# Altium schematic sheet stm32wl_Power.SchDoc(stm32wl_Power)
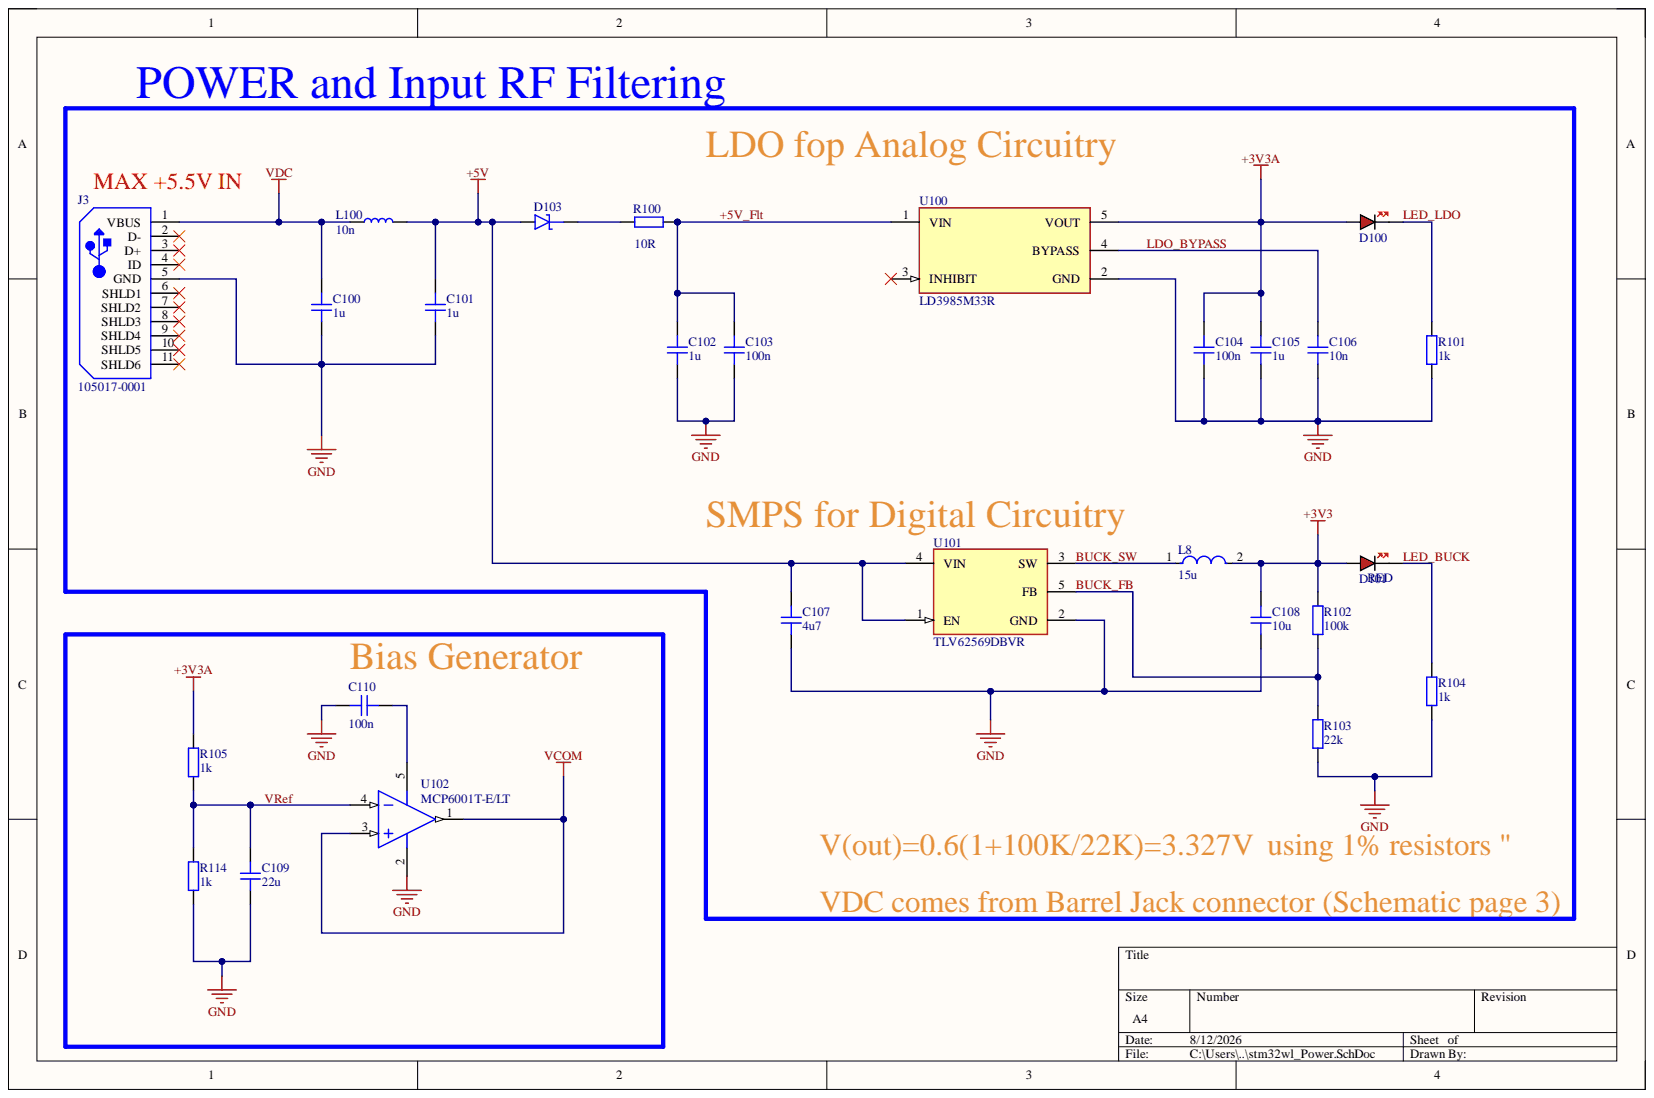
[diagram p0: stm32wl_Power.SchDoc]

## Parts
C100  1u  [CAP_0603]
C101  1u  [CAP_0603]
C102  1u  [CAP_0603]
C103  100n  [CAP_0603]
C104  100n  [CAP_0603]
C105  1u  [CAP_0603]
C106  10n  [CAP_0603]
C107  4u7  [CAP_0603]
C108  10u  [CAP_0603]
C109  22u  [CAP_0603]
C110  100n  [CAP_0402]
D100  RED  [LED_0402_RED]  (value not drawn)
D101  RED  [LED_0402_RED]
D103  D_SCHOTTKY_SOD_323  [SOD-323]  (value not drawn)
J3  105017-0001  [USB-MICRO-B_V]
L8  15u  [FP-IHLP-2020CZ-FP_1_905x2-MFG]
L100  10n  [IND_0603]
R100  10R  [RES_0603]
R101  1k  [RES_0402]
R102  100k  [RES_0402]
R103  22k  [RES_0402]
R104  1k  [RES_0402]
R105  1k  [RES_0402]
R114  1k  [RES_0402]
U100  LD3985M33R  [FP-SOT23-5L-7049676-MFG]
U101  TLV62569DBVR  [FP-DBV0005A-MFG]
U102  MCP6001T-E/LT  [SC70-LT5_L]

## Nets
+3V3: C108.2 D101.A L8.2 R102.2
+3V3A: C104.2 C105.2 D100.A R105.2 U100.5(VOUT)
+5V: C101.2 C107.2 D103.A L100.2 U101.1(EN) U101.4(VIN)
GND: C100.1 C101.1 C102.1 C103.1 C104.1 C105.1 C106.1 C107.1 C108.1 C109.1 C110.2 J3.5(GND) R101.1 R103.1 R104.1 R114.1 U100.2(GND) U101.2(GND) U102.2
VCOM: U102.1 U102.3
VDC: C100.2 J3.1(VBUS) L100.1
+5V_Flt: C102.2 C103.2 R100.2 U100.1(VIN)
BUCK_FB: R102.1 R103.2 U101.5(FB)
BUCK_SW: L8.1 U101.3(SW)
LDO_BYPASS: C106.2 U100.4(BYPASS)
LED_BUCK: D101.K R104.2
LED_LDO: D100.K R101.2
VRef: C109.2 R105.1 R114.2 U102.4
NetC110_1: C110.1 U102.5
NetD103_K: D103.K R100.1

Not connected: J3 2(D-) 3(D+) 4(ID) 6(SHLD1) 7(SHLD2) 8(SHLD3) 9(SHLD4) 10(SHLD5) 11(SHLD6); U100 3(INHIBIT)

## Library data of the parts
C100-C109 = CAP_0603_16V: Capacitor SMD 0603 16V; Component Kind: Standard; Library Name: Schematic-Lib-PhilsLab.SchLib
C110 = CAP_0402_16V: Capacitor SMD 0402 16V; Component Kind: Standard; Library Name: Schematic-Lib-PhilsLab.SchLib
D100 D101 = LED_0402_RED: LED 0402 RED SMD; Component Kind: Standard; Library Name: Schematic-Lib-PhilsLab.SchLib
D103 = D_SCHOTTKY_SOD_323: Diode Schottky SMD SOD-323; Component Kind: Standard; Library Name: Schematic-Lib-PhilsLab.SchLib
J3 = CMP-2000-05827-1: Micro-USB B Receptacle, Right Angle, Bottom Mount, Surface Mount, with Solder Tabs, -30 to 85 degC, 5-Pin USB, RoHS, Tape and Reel; Manufacturer: Molex; 3D Model: 105017-0001.stp; Catalog Drawings: 1050170001; Circuits: 5; Connector Type: USB-microB; Featured Product: Micro-USBConnectorsUSBConnectors; Mating Products: WM17145-ND-CABLEMICROUSBBTOSTDA1.0MWM17147-ND-CABLEMICROUSBBTOSTDA2.0MWM17146-ND-CABLEMICROUSBBTOSTDA1.5M; Online Catalog: Molex-MicroUSB; Other Names: WM1399DKR; Package Version: Rev. F, 12/2012; PCN Assembly/Origin: ResinSupplier21/Oct/2015; Voltage - Max: 30V; Category: Connectors,Interconnects; Component Kind: Standard; Datasheet URL: http://www.molex.com/elqNow/elqRedir.htm?ref=http://www.molex.com/webdocs/datasheets/pdf/en-us/1050170001_IO_CONNECTORS.pdf; Datasheet Version: 03/2016; Family: USB,DVI,HDMIConnectors; Features: Shielded,SolderRetention; Gender: Receptacle; Ingress Protection: -; Library Name: Altium Content Vault; Manufacturer URL: http://www.molex.com/; Mounting Technology: SurfaceMount; Mounting Type: BoardCutout,SurfaceMount,RightAngle; Number of Contacts: 5; Package Description: 5-Leads USB, Micro B, Body 7.5 x 5 mm, Pitch 0.65 mm; Package Reference: MICRO-B; Package URL: http://www.molex.com/pdm_docs/sd/1050170001_sd.pdf; Packaging: Digi-Reel®; RoHS Information: 1050170001CertofCompliance; Series: -; Specifications: -; Standard Package: 1
L8 = CMP-02424-000234-1: Fixed Inductors Commercial Low DCR Series 2.2uH 6.75A 25mΩ 20%; Core Material: Metal; DC Resistance (DCR): 25mR; Max DC Current: 5.5A; Self Resonant Frequency: 41MHz; Shielding: Shielded; Test Frequency: 100kHz; Case/Package: 2020; Component Kind: Standard; Contact Plating: Tin; Current Rating: 6.75A; Depth: 5.43mm; Height: 3mm; Height - Seated (Max): 0.118inch; Inductance: 2.2uH; Inductance Tolerance: 20%; Lead Free: Lead Free; Length: 5.74mm; Library Name: Altium Content Vault; Max Operating Temperature: 125°C; Military Standard: Not; Min Operating Temperature: -55°C; Mount: Surface Mount; Package Quantity: 2000; Packaging: Tape and Reel; Radiation Hardening: No; RMS Current (Irms): 6.75A; Saturation Current: 5.5A; Termination: SMD/SMT; Tolerance: 20%; Width: 0.204inch
L100 = IND_0603: Inductor SMD 0603; Component Kind: Standard; Library Name: Schematic-Lib-PhilsLab.SchLib
R100 = RES_0603: Resistor SMD 0603; Component Kind: Standard; Library Name: Schematic-Lib-PhilsLab.SchLib
R101-R105 R114 = RES_0402: Resistor SMD 0402; Component Kind: Standard; Library Name: Schematic-Lib-PhilsLab.SchLib
U100 = CMP-0244-00328-2: IC REG LINEAR 3.3V 150MA SOT23-5; Accuracy: 2%; Dropout Voltage: 60mV; Input Bias Current: 85uA; Max Input Voltage: 6V; Min Current Limit: 300mA; Min Input Voltage: 2.5V; Number of Outputs: 1; Output Type: Fixed; Polarity: Positive; Quiescent Current: 150uA; Case/Package: SOT-23; Component Kind: Standard; Height: 1.3mm; Lead Free: Lead Free; Length: 3mm; Library Name: Altium Content Vault; Max Operating Temperature: 125°C; Max Output Current: 150mA; Min Operating Temperature: -40°C; Min Output Voltage: 3.3V; Mount: Surface Mount; Number of Pins: 5; Number of Regulators: 1; Output Current: 150mA; Output Voltage: 3.3V; Output Voltage Accuracy: 2%; Package Quantity: 1; Packaging: Tape and Reel; Radiation Hardening: No; Width: 1.75mm
U101 = CMP-04918-000387-1: IC REG BUCK ADJ 2A SOT23-5; Max Input Voltage: 5.5V; Min Input Voltage: 2.5V; Min Output Voltage: 600mV; Output Configuration: Positive; Output Type: Adjustable; Switching Frequency: 1.5MHz; Synchronous Rectifier: Yes; Case/Package: SOT-23-5; Component Kind: Standard; Library Name: Altium Content Vault; Max Operating Temperature: 125°C; Max Output Voltage: 5.5V; Min Operating Temperature: -40°C; Mount: Surface Mount; Output Current: 2A; Packaging: Cut Tape; RoHS Compliant: Yes
U102 = CMP-0187-00463-3: None; Amplifier Type: GENERAL PURPOSE; Bandwidth: 1MHz; Common Mode Rejection Ratio: 60dB; Input Bias Current: 1pA; Input Offset Voltage (Vos): 4.5mV; Max Supply Voltage: 6V; Min Supply Voltage: 1.8V; Nominal Supply Current: 100uA; Number of Amplifiers: 1; Operating Supply Voltage: 5.5V; Output Current: 23mA; Power Supply Rejection Ratio (PSRR): 86dB; Slew Rate: 0.6V/µs; Voltage Gain: 112dB; Case/Package: SC; Component Kind: Standard; Contact Plating: Tin; Gain Bandwidth Product: 1MHz; Height: 1mm; Input Offset Voltage-Max: 4.5mV; Lead Free: Lead Free; Length: 2.25mm; Library Name: Altium Content Vault; Max Operating Temperature: 125°C; Min Operating Temperature: -40°C; Mount: Surface Mount; Number of Channels: 1; Number of Circuits: 1; Number of Elements: 1; Number of Pins: 5; Package Quantity: 3000; Packaging: Tape and Reel; Radiation Hardening: No; REACH SVHC: No SVHC; RoHS Compliant: Yes; Simulation: MCP6001; Slew Rate-Nom: 0.6V/µs; Supply Current: 100uA; Technology: CMOS; Unity Gain Bandwidth Product: 1MHz; Width: 1.35mm
